# Altium schematic sheet heating_schematic_1.SchDoc (continued)

## Library data of the parts
C1 = EEU-FC1H471L: Capacitor Aluminum Electrolytic 470uF 50 Volt 20% (10 X 30mm) Radial 5mm 1610mA 3000h 105C Bulk; Manufacturer: Panasonic; Manufacturer Lifecycle Status: ACTIVE; Part Series: FC-A; Dielectric Material: Aluminium; Dissipation Factor: 10%; ESR (Equivalent Series Resistance): 60mΩ; Lead Diameter: 600µm; Leakage Current: 235µA; Life (Hours): 3000hours; Ripple Current: 1.61A; Voltage: 50V; Case/Package: Radial; Category: Aluminum Electrolytic Capacitors; Component Kind: Standard; ComponentLink1Description: https://datasheet.octopart.com:443/EEU-FC1H471L-Panasonic-datasheet-68300023.pdf?src-supplier=Panasonic; ComponentLink1URL: https://datasheet.octopart.com:443/EEU-FC1H471L-Panasonic-datasheet-68300023.pdf?src-supplier=Panasonic; ComponentLink2Description: https://datasheet.octopart.com:443/EEU-FC1H471L-Panasonic-datasheet-13266282.pdf?src-supplier=Farnell; ComponentLink2URL: https://datasheet.octopart.com:443/EEU-FC1H471L-Panasonic-datasheet-13266282.pdf?src-supplier=Farnell; ComponentLink3Description: https://datasheet.octopart.com:443/EEU-FC1H471L-Panasonic-datasheet-5313828.pdf?src-supplier=Farnell; ComponentLink3URL: https://datasheet.octopart.com:443/EEU-FC1H471L-Panasonic-datasheet-5313828.pdf?src-supplier=Farnell; ComponentLink4Description: https://datasheet.octopart.com:443/EEU-FC1H471L-Panasonic-datasheet-136608605.pdf?src-supplier=Farnell; ComponentLink4URL: https://datasheet.octopart.com:443/EEU-FC1H471L-Panasonic-datasheet-136608605.pdf?src-supplier=Farnell; ComponentLink5Description: https://datasheet.octopart.com:443/EEU-FC1H471L-Panasonic-datasheet-42901146.pdf?src-supplier=Newark; ComponentLink5URL: https://datasheet.octopart.com:443/EEU-FC1H471L-Panasonic-datasheet-42901146.pdf?src-supplier=Newark; ComponentLink6Description: https://datasheet.octopart.com:443/EEU-FC1H471L-Panasonic-datasheet-38887810.pdf?src-supplier=Newark; ComponentLink6URL: https://datasheet.octopart.com:443/EEU-FC1H471L-Panasonic-datasheet-38887810.pdf?src-supplier=Newark; ComponentLink7Description: https://datasheet.octopart.com:443/EEU-FC1H471L-Panasonic-datasheet-154766098.pdf?src-supplier=Master+Electronics; ComponentLink7URL: https://datasheet.octopart.com:443/EEU-FC1H471L-Panasonic-datasheet-154766098.pdf?src-supplier=Master+Electronics; ComponentLink8Description: https://datasheet.octopart.com:443/EEU-FC1H471L-Panasonic-datasheet-10025751.pdf?src-supplier=RS+(Formerly+Allied+Electronics); ComponentLink8URL: https://datasheet.octopart.com:443/EEU-FC1H471L-Panasonic-datasheet-10025751.pdf?src-supplier=RS+(Formerly+Allied+Electronics); ComponentLink9Description: https://datasheet.octopart.com:443/EEU-FC1H471L-Panasonic-datasheet-22087617.pdf?src-supplier=Electro+Sonic; ComponentLink9URL: https://datasheet.octopart.com:443/EEU-FC1H471L-Panasonic-datasheet-22087617.pdf?src-supplier=Electro+Sonic; ComponentLink10Description: https://datasheet.octopart.com:443/EEUFC1H471L-Panasonic-datasheet-150469839.pdf?src-supplier=TME; ComponentLink10URL: https://datasheet.octopart.com:443/EEUFC1H471L-Panasonic-datasheet-150469839.pdf?src-supplier=TME; ComponentLink11Description: https://datasheet.octopart.com:443/EEU-FC1H471L-Panasonic-datasheet-2252.pdf?src-supplier=DigiKey; ComponentLink11URL: https://datasheet.octopart.com:443/EEU-FC1H471L-Panasonic-datasheet-2252.pdf?src-supplier=DigiKey; Diameter: 10mm; Height: 32mm; Height - Seated (Max): 29.9974mm; Impedance: 60mΩ; Lead Free: Lead Free; Lead Pitch: 5mm; Length: 30mm; Library Name: Simulation Generic Components.IntLib; Lifecycle Status: Production; Manufacturer Part Number: EEU-FC1H471L; Material: Aluminium; Max Operating Temperature: 105°C; Min Operating Temperature: -55°C; Mounting Technology: PCB, Through Hole; Number of Terminals: 2; Packaging: Bulk; Pins: 2; Pricing: 1=1.39, 10=0.897, 50=0.6894, 100=0.6237, 500=0.51066, 1000=0.47503, 2500=0.43702, 5000=0.41386 (USD); Radiation Hardening: No; REACH SVHC: Yes; RoHS: Compliant; Schedule B: 8532220020, 8532220020|8532220020, 8532220020|8532220020|8532220020, 8532220020|8532220020|8532220020|8532220020; Stock: 46796; Supplier: DigiKey; Supplier Part Number: P11260-ND; Terminal Pitch: 5mm; Termination: Radial; Tolerance: 20%; Voltage Rating: 50V; Voltage Rating (DC): 50V; Width: 10mm
C2 = CC0603KRX7R9BB104: Multilayer Ceramic Capacitor, CC Series, 0.1 - F, - 10%, X7R, 50 V, 0603 [1608 Metric]; Manufacturer: Yageo Group; Voltage: 50V; Case Code (Imperial): 0603; Case Code (Metric): 1608; Case/Package: 0603; Category: Ceramic Capacitors; China RoHS: Compliant; Component Kind: Standard; ComponentLink1Description: https://datasheet.octopart.com:443/CC0603KRX7R9BB104-Yageo-datasheet-177165144.pdf?src-supplier=IHS; ComponentLink1URL: https://datasheet.octopart.com:443/CC0603KRX7R9BB104-Yageo-datasheet-177165144.pdf?src-supplier=IHS; ComponentLink2Description: https://datasheet.octopart.com:443/CC0603KRX7R9BB104-Yageo-datasheet-82288757.pdf?src-supplier=Upverter; ComponentLink2URL: https://datasheet.octopart.com:443/CC0603KRX7R9BB104-Yageo-datasheet-82288757.pdf?src-supplier=Upverter; ComponentLink3Description: https://datasheet.octopart.com:443/CC0603KRX7R9BB104-Yageo-datasheet-68298032.pdf?src-supplier=Upverter; ComponentLink3URL: https://datasheet.octopart.com:443/CC0603KRX7R9BB104-Yageo-datasheet-68298032.pdf?src-supplier=Upverter; ComponentLink4Description: https://datasheet.octopart.com:443/CC0603KRX7R9BB104-Yageo-Group-datasheet-189192896.pdf?src-supplier=Future+Electronics; ComponentLink4URL: https://datasheet.octopart.com:443/CC0603KRX7R9BB104-Yageo-Group-datasheet-189192896.pdf?src-supplier=Future+Electronics; ComponentLink5Description: https://datasheet.octopart.com:443/CC0603KRX7R9BB104-Yageo-datasheet-12526321.pdf?src-supplier=Future+Electronics; ComponentLink5URL: https://datasheet.octopart.com:443/CC0603KRX7R9BB104-Yageo-datasheet-12526321.pdf?src-supplier=Future+Electronics; ComponentLink6Description: https://datasheet.octopart.com:443/CC0603KRX7R9BB104-Yageo-datasheet-166511530.pdf?src-supplier=Future+Electronics; ComponentLink6URL: https://datasheet.octopart.com:443/CC0603KRX7R9BB104-Yageo-datasheet-166511530.pdf?src-supplier=Future+Electronics; ComponentLink7Description: https://datasheet.octopart.com:443/CC0603KRX7R9BB104-Yageo-datasheet-137700082.pdf?src-supplier=Future+Electronics; ComponentLink7URL: https://datasheet.octopart.com:443/CC0603KRX7R9BB104-Yageo-datasheet-137700082.pdf?src-supplier=Future+Electronics; ComponentLink8Description: https://datasheet.octopart.com:443/CC0603KRX7R9BB104-Yageo-datasheet-137700084.pdf?src-supplier=Future+Electronics; ComponentLink8URL: https://datasheet.octopart.com:443/CC0603KRX7R9BB104-Yageo-datasheet-137700084.pdf?src-supplier=Future+Electronics; ComponentLink9Description: https://datasheet.octopart.com:443/CC0603KRX7R9BB104-Yageo-datasheet-27626582.pdf?src-supplier=Future+Electronics; ComponentLink9URL: https://datasheet.octopart.com:443/CC0603KRX7R9BB104-Yageo-datasheet-27626582.pdf?src-supplier=Future+Electronics; ComponentLink10Description: https://datasheet.octopart.com:443/CC0603KRX7R9BB104-Yageo-datasheet-15895757.pdf?src-supplier=Future+Electronics; ComponentLink10URL: https://datasheet.octopart.com:443/CC0603KRX7R9BB104-Yageo-datasheet-15895757.pdf?src-supplier=Future+Electronics; ComponentLink11Description: https://datasheet.octopart.com:443/CC0603KRX7R9BB104-Yageo-datasheet-8324192.pdf?src-supplier=Future+Electronics; ComponentLink11URL: https://datasheet.octopart.com:443/CC0603KRX7R9BB104-Yageo-datasheet-8324192.pdf?src-supplier=Future+Electronics; ComponentLink12Description: https://datasheet.octopart.com/CC0603KRX7R9BB104-Yageo-datasheet-10883670.pdf; ComponentLink12URL: https://datasheet.octopart.com/CC0603KRX7R9BB104-Yageo-datasheet-10883670.pdf; ComponentLink13Description: https://datasheet.octopart.com:443/CC0603KRX7R9BB104-Yageo-datasheet-181968726.pdf?src-supplier=Newark; ComponentLink13URL: https://datasheet.octopart.com:443/CC0603KRX7R9BB104-Yageo-datasheet-181968726.pdf?src-supplier=Newark; ComponentLink14Description: https://datasheet.octopart.com:443/CC0603KRX7R9BB104-Yageo-datasheet-499490.pdf?src-supplier=Newark; ComponentLink14URL: https://datasheet.octopart.com:443/CC0603KRX7R9BB104-Yageo-datasheet-499490.pdf?src-supplier=Newark; ComponentLink15Description: https://datasheet.octopart.com:443/CC0603KRX7R9BB104-Yageo-datasheet-62336348.pdf?src-supplier=Newark; ComponentLink15URL: https://datasheet.octopart.com:443/CC0603KRX7R9BB104-Yageo-datasheet-62336348.pdf?src-supplier=Newark; ComponentLink16Description: https://datasheet.octopart.com:443/CC0603KRX7R9BB104-Yageo-datasheet-147453679.pdf?src-supplier=Newark; ComponentLink16URL: https://datasheet.octopart.com:443/CC0603KRX7R9BB104-Yageo-datasheet-147453679.pdf?src-supplier=Newark; ComponentLink17Description: https://datasheet.octopart.com:443/CC0603KRX7R9BB104-Yageo-datasheet-151948686.pdf?src-supplier=Farnell; ComponentLink17URL: https://datasheet.octopart.com:443/CC0603KRX7R9BB104-Yageo-datasheet-151948686.pdf?src-supplier=Farnell; ComponentLink18Description: https://datasheet.octopart.com:443/CC0603KRX7R9BB104-Yageo-datasheet-500777.pdf?src-supplier=Farnell; ComponentLink18URL: https://datasheet.octopart.com:443/CC0603KRX7R9BB104-Yageo-datasheet-500777.pdf?src-supplier=Farnell; ComponentLink19Description: https://datasheet.octopart.com:443/CC0603KRX7R9BB104-Yageo-datasheet-178019014.pdf?src-supplier=Burklin+Elektronik; ComponentLink19URL: https://datasheet.octopart.com:443/CC0603KRX7R9BB104-Yageo-datasheet-178019014.pdf?src-supplier=Burklin+Elektronik; ComponentLink20Description: https://datasheet.octopart.com:443/CC0603KRX7R9BB104-Yageo-Group-datasheet-165992755.pdf?src-supplier=ODG+(Origin+Data+Global); ComponentLink20URL: https://datasheet.octopart.com:443/CC0603KRX7R9BB104-Yageo-Group-datasheet-165992755.pdf?src-supplier=ODG+(Origin+Data+Global); ComponentLink21Description: https://datasheet.octopart.com:443/CC0603KRX7R9BB104-Yageo-datasheet-140260305.pdf?src-supplier=SOS+electronic; ComponentLink21URL: https://datasheet.octopart.com:443/CC0603KRX7R9BB104-Yageo-datasheet-140260305.pdf?src-supplier=SOS+electronic; ComponentLink22Description: https://datasheet.octopart.com:443/CC0603KRX7R9BB104-Yageo-datasheet-120101875.pdf?src-supplier=Megastar; ComponentLink22URL: https://datasheet.octopart.com:443/CC0603KRX7R9BB104-Yageo-datasheet-120101875.pdf?src-supplier=Megastar; ComponentLink23Description: https://datasheet.octopart.com:443/CC0603KRX7R9BB104-Yageo-datasheet-8701427.pdf?src-supplier=element14; ComponentLink23URL: https://datasheet.octopart.com:443/CC0603KRX7R9BB104-Yageo-datasheet-8701427.pdf?src-supplier=element14; ComponentLink24Description: https://datasheet.octopart.com:443/CC0603KRX7R9BB104-Yageo-datasheet-5301394.pdf?src-supplier=element14; ComponentLink24URL: https://datasheet.octopart.com:443/CC0603KRX7R9BB104-Yageo-datasheet-5301394.pdf?src-supplier=element14; ComponentLink25Description: https://datasheet.octopart.com:443/CC0603KRX7R9BB104-Yageo-datasheet-17600895.pdf?src-supplier=TME; ComponentLink25URL: https://datasheet.octopart.com:443/CC0603KRX7R9BB104-Yageo-datasheet-17600895.pdf?src-supplier=TME; ComponentLink26Description: http://sigma.octopart.com:80/15889575/rohs_statement/Yageo-CC0603KRX7R9BB104.pdf?src-supplier=Compliance+Statements; ComponentLink26URL: http://sigma.octopart.com:80/15889575/rohs_statement/Yageo-CC0603KRX7R9BB104.pdf?src-supplier=Compliance+Statements; ComponentLink27Description: http://sigma.octopart.com:80/conflict_mineral_statement/Yageo-company-3.pdf?src-supplier=Compliance+Statements; ComponentLink27URL: http://sigma.octopart.com:80/conflict_mineral_statement/Yageo-company-3.pdf?src-supplier=Compliance+Statements; Country of Origin: Mainland China, Taiwan; Depth: 800µm; Dielectric: X7R; Dielectric Material: Ceramic; Export Control Classification Number (ECCN) Code: EAR99; Halogen Free: Halogen Free; Harmonized Tariff Schedule (HTS) Code: 8532.24.00.20; Height: 800µm; Introduction Date: 2005-08-18; Lead Free: Lead Free; Length: 1.6mm; Library Name: Simulation Generic Components.IntLib; Lifecycle Status: Production; Manufacturer Part Number: CC0603KRX7R9BB104; Material: Ceramic; Max Operating Temperature: 125°C; Min Operating Temperature: -55°C; Mounting Technology: Surface Mount; Number of Terminals: 2; Pins: 2; Pricing: 1=0.08, 10=0.024, 50=0.0176, 100=0.0112, 500=0.0104, 1000=0.0096 (USD); Radiation Hardening: No; REACH SVHC: Yes; RoHS: Compliant; Schedule B: 8532240020, 8532240020|8532240020, 8532240020|8532240020|8532240020, 8532240020|8532240020|8532240020|8532240020; Stock: 2142675; Supplier: DigiKey; Supplier Part Number: 311-1344-1-ND; Termination: SMD/SMT; Thickness: 889µm; Tolerance: 10%; Voltage Rating: 50V; Voltage Rating (DC): 50V; Width: 800µm
C3 = CC0603KRX7R9BB105: 50V 1uF X7R ±10% 0603 Multilayer Ceramic Capacitors MLCC - SMD/SMT ROHS; Manufacturer: Yageo Group; Case Code (Imperial): 0603; Case Code (Metric): 1608; Case/Package: 0603; Category: Ceramic Capacitors; China RoHS: Compliant; Component Kind: Standard; ComponentLink1Description: https://datasheet.octopart.com:443/CC0603KRX7R9BB105-Yageo-datasheet-177165144.pdf?src-supplier=IHS; ComponentLink1URL: https://datasheet.octopart.com:443/CC0603KRX7R9BB105-Yageo-datasheet-177165144.pdf?src-supplier=IHS; ComponentLink2Description: https://datasheet.octopart.com:443/CC0603KRX7R9BB105-Yageo-datasheet-82288757.pdf?src-supplier=Upverter; ComponentLink2URL: https://datasheet.octopart.com:443/CC0603KRX7R9BB105-Yageo-datasheet-82288757.pdf?src-supplier=Upverter; ComponentLink3Description: https://datasheet.octopart.com:443/CC0603KRX7R9BB105-Yageo-datasheet-149070022.pdf?src-supplier=Upverter; ComponentLink3URL: https://datasheet.octopart.com:443/CC0603KRX7R9BB105-Yageo-datasheet-149070022.pdf?src-supplier=Upverter; ComponentLink4Description: https://sigma.octopart.com:443/147830939/technical_drawing/Yageo-CC0603KRX7R9BB105.pdf?src-supplier=Upverter; ComponentLink4URL: https://sigma.octopart.com:443/147830939/technical_drawing/Yageo-CC0603KRX7R9BB105.pdf?src-supplier=Upverter; ComponentLink5Description: https://datasheet.octopart.com:443/CC0603KRX7R9BB105-Yageo-datasheet-181968729.pdf?src-supplier=Newark; ComponentLink5URL: https://datasheet.octopart.com:443/CC0603KRX7R9BB105-Yageo-datasheet-181968729.pdf?src-supplier=Newark; ComponentLink6Description: https://datasheet.octopart.com:443/CC0603KRX7R9BB105-Yageo-datasheet-147453679.pdf?src-supplier=Newark; ComponentLink6URL: https://datasheet.octopart.com:443/CC0603KRX7R9BB105-Yageo-datasheet-147453679.pdf?src-supplier=Newark; ComponentLink7Description: https://datasheet.octopart.com:443/CC0603KRX7R9BB105-Yageo-datasheet-181964739.pdf?src-supplier=Farnell; ComponentLink7URL: https://datasheet.octopart.com:443/CC0603KRX7R9BB105-Yageo-datasheet-181964739.pdf?src-supplier=Farnell; ComponentLink8Description: https://datasheet.octopart.com:443/CC0603KRX7R9BB105-Yageo-datasheet-151948686.pdf?src-supplier=TME; ComponentLink8URL: https://datasheet.octopart.com:443/CC0603KRX7R9BB105-Yageo-datasheet-151948686.pdf?src-supplier=TME; ComponentLink9Description: https://datasheet.octopart.com:443/CC0603KRX7R9BB105-Yageo-datasheet-166511530.pdf?src-supplier=Future+Electronics; ComponentLink9URL: https://datasheet.octopart.com:443/CC0603KRX7R9BB105-Yageo-datasheet-166511530.pdf?src-supplier=Future+Electronics; ComponentLink10Description: https://datasheet.octopart.com:443/CC0603KRX7R9BB105-Yageo-datasheet-137700082.pdf?src-supplier=Future+Electronics; ComponentLink10URL: https://datasheet.octopart.com:443/CC0603KRX7R9BB105-Yageo-datasheet-137700082.pdf?src-supplier=Future+Electronics; ComponentLink11Description: https://datasheet.octopart.com:443/CC0603KRX7R9BB105-Yageo-Group-datasheet-165992755.pdf?src-supplier=ODG+(Origin+Data+Global); ComponentLink11URL: https://datasheet.octopart.com:443/CC0603KRX7R9BB105-Yageo-Group-datasheet-165992755.pdf?src-supplier=ODG+(Origin+Data+Global); ComponentLink12Description: https://datasheet.octopart.com/Surface-Mount-Ceramic-Multilayer-Capacitors%3B-General-Purpose-%26-High-Capacitance-Class-2%2C-X7R-Yageo-datasheet-family-114.pdf; ComponentLink12URL: https://datasheet.octopart.com/Surface-Mount-Ceramic-Multilayer-Capacitors%3B-General-Purpose-%26-High-Capacitance-Class-2%2C-X7R-Yageo-datasheet-family-114.pdf; ComponentLink13Description: https://datasheet.octopart.com/CC0603KRX7R9BB105-Yageo-datasheet-10883670.pdf; ComponentLink13URL: https://datasheet.octopart.com/CC0603KRX7R9BB105-Yageo-datasheet-10883670.pdf; ComponentLink14Description: http://sigma.octopart.com:80/rohs_statement/Surface-Mount-Ceramic-Multilayer-Capacitors%3B-General-Purpose-%26-High-Capacitance-Class-2%2C-X7R-Yageo-family-124.pdf?src-supplier=Compliance+Statements; ComponentLink14URL: http://sigma.octopart.com:80/rohs_statement/Surface-Mount-Ceramic-Multilayer-Capacitors%3B-General-Purpose-%26-High-Capacitance-Class-2%2C-X7R-Yageo-family-124.pdf?src-supplier=Compliance+Statements; ComponentLink15Description: http://sigma.octopart.com:80/reach_statement/Surface-Mount-Ceramic-Multilayer-Capacitors%3B-General-Purpose-%26-High-Capacitance-Class-2%2C-X7R-Yageo-family-125.pdf?src-supplier=Compliance+Statements; ComponentLink15URL: http://sigma.octopart.com:80/reach_statement/Surface-Mount-Ceramic-Multilayer-Capacitors%3B-General-Purpose-%26-High-Capacitance-Class-2%2C-X7R-Yageo-family-125.pdf?src-supplier=Compliance+Statements; ComponentLink16Description: http://sigma.octopart.com:80/conflict_mineral_statement/Yageo-company-3.pdf?src-supplier=Compliance+Statements; ComponentLink16URL: http://sigma.octopart.com:80/conflict_mineral_statement/Yageo-company-3.pdf?src-supplier=Compliance+Statements; ComponentLink17Description: http://sigma.octopart.com:80/rohs_statement/Yageo-company-2.pdf?src-supplier=Compliance+Statements; ComponentLink17URL: http://sigma.octopart.com:80/rohs_statement/Yageo-company-2.pdf?src-supplier=Compliance+Statements; Composition: Ceramic; Country of Origin: Mainland China, Taiwan; Dielectric: X7R; Dielectric Material: Ceramic; Export Control Classification Number (ECCN) Code: EAR99; Halogen Free: Halogen Free; Harmonized Tariff Schedule (HTS) Code: 8532.24.00.20; Height: 800µm; Introduction Date: 2014-07-08; Lead Free: Lead Free; Length: 1.6mm; Library Name: Simulation Generic Components.IntLib; Lifecycle Status: Production; Manufacturer Part Number: CC0603KRX7R9BB105; Material: Ceramic; Max Operating Temperature: 125°C; Min Operating Temperature: -55°C; Mounting Technology: Surface Mount; Number of Terminals: 2; Packaging: Tape & Reel (TR); Pricing: 1=0.47, 10=0.284, 50=0.2124, 100=0.1902, 500=0.15282, 1000=0.14128, 4000=0.12356, 8000=0.11755, 12000=0.11433, 20000=0.11245 (USD); REACH SVHC: Yes; RoHS: Compliant; Schedule B: 8532240020, 8532240020|8532240020|8532240020|8532240020|8532240020; Stock: 144229; Supplier: DigiKey; Supplier Part Number: 311-2082-1-ND; Termination: SMD/SMT; Thickness: 889µm; Tolerance: 10%; Voltage Rating: 50V; Voltage Rating (DC): 50V; Weight: 2.012816mg; Width: 800µm
C4 = GRM32ER72A225KA35L: IRON_BUCK_CIN: 100V 2.2uF X7R ±10% 1210 Multilayer Ceramic Capacitors MLCC - SMD/SMT ROHS; Manufacturer: Murata; Manufacturer Lifecycle Status: NRND; Case Code (Imperial): 1210; Case Code (Metric): 3225; Case/Package: 1210; Category: Ceramic Capacitors; Component Kind: Standard; ComponentLink1Description: https://datasheet.octopart.com:443/GRM32ER72A225KA35L-Murata-datasheet-11840456.pdf?src-supplier=_legacy+Avnet; ComponentLink1URL: https://datasheet.octopart.com:443/GRM32ER72A225KA35L-Murata-datasheet-11840456.pdf?src-supplier=_legacy+Avnet; ComponentLink2Description: https://datasheet.octopart.com:443/GRM32ER72A225KA35L-Murata-datasheet-8817987.pdf?src-supplier=element14+APAC; ComponentLink2URL: https://datasheet.octopart.com:443/GRM32ER72A225KA35L-Murata-datasheet-8817987.pdf?src-supplier=element14+APAC; ComponentLink3Description: https://datasheet.octopart.com:443/GRM32ER72A225KA35L-Murata-datasheet-62320274.pdf?src-supplier=element14+APAC; ComponentLink3URL: https://datasheet.octopart.com:443/GRM32ER72A225KA35L-Murata-datasheet-62320274.pdf?src-supplier=element14+APAC; ComponentLink4Description: https://datasheet.octopart.com:443/GRM32ER72A225KA35L-Murata-datasheet-8376856.pdf?src-supplier=Future+Electronics; ComponentLink4URL: https://datasheet.octopart.com:443/GRM32ER72A225KA35L-Murata-datasheet-8376856.pdf?src-supplier=Future+Electronics; ComponentLink5Description: https://datasheet.octopart.com:443/GRM32ER72A225KA35L-Murata-datasheet-14397864.pdf?src-supplier=Future+Electronics; ComponentLink5URL: https://datasheet.octopart.com:443/GRM32ER72A225KA35L-Murata-datasheet-14397864.pdf?src-supplier=Future+Electronics; ComponentLink6Description: https://datasheet.octopart.com:443/GRM32ER72A225KA35L-Murata-datasheet-15666908.pdf?src-supplier=Future+Electronics; ComponentLink6URL: https://datasheet.octopart.com:443/GRM32ER72A225KA35L-Murata-datasheet-15666908.pdf?src-supplier=Future+Electronics; ComponentLink7Description: https://datasheet.octopart.com:443/GRM32ER72A225KA35L-Murata-datasheet-12510170.pdf?src-supplier=Future+Electronics; ComponentLink7URL: https://datasheet.octopart.com:443/GRM32ER72A225KA35L-Murata-datasheet-12510170.pdf?src-supplier=Future+Electronics; ComponentLink8Description: https://datasheet.octopart.com:443/GRM32ER72A225KA35L-Murata-datasheet-8517407.pdf?src-supplier=Future+Electronics; ComponentLink8URL: https://datasheet.octopart.com:443/GRM32ER72A225KA35L-Murata-datasheet-8517407.pdf?src-supplier=Future+Electronics; ComponentLink9Description: https://datasheet.octopart.com:443/GRM32ER72A225KA35L-Murata-datasheet-8387045.pdf?src-supplier=Future+Electronics; ComponentLink9URL: https://datasheet.octopart.com:443/GRM32ER72A225KA35L-Murata-datasheet-8387045.pdf?src-supplier=Future+Electronics; ComponentLink10Description: https://datasheet.octopart.com:443/GRM32ER72A225KA35L-Murata-datasheet-8324201.pdf?src-supplier=Future+Electronics; ComponentLink10URL: https://datasheet.octopart.com:443/GRM32ER72A225KA35L-Murata-datasheet-8324201.pdf?src-supplier=Future+Electronics; ComponentLink11Description: https://datasheet.octopart.com:443/GRM32ER72A225KA35L-Murata-datasheet-7628724.pdf?src-supplier=Future+Electronics; ComponentLink11URL: https://datasheet.octopart.com:443/GRM32ER72A225KA35L-Murata-datasheet-7628724.pdf?src-supplier=Future+Electronics; ComponentLink12Description: https://datasheet.octopart.com:443/GRM32ER72A225KA35L-Murata-datasheet-552801.pdf?src-supplier=Future+Electronics; ComponentLink12URL: https://datasheet.octopart.com:443/GRM32ER72A225KA35L-Murata-datasheet-552801.pdf?src-supplier=Future+Electronics; ComponentLink13Description: https://datasheet.octopart.com:443/GRM32ER72A225KA35L-Murata-datasheet-44109779.pdf?src-supplier=Future+Electronics; ComponentLink13URL: https://datasheet.octopart.com:443/GRM32ER72A225KA35L-Murata-datasheet-44109779.pdf?src-supplier=Future+Electronics; ComponentLink14Description: https://datasheet.octopart.com:443/GRM32ER72A225KA35L-Murata-datasheet-8138834.pdf?src-supplier=Newark; ComponentLink14URL: https://datasheet.octopart.com:443/GRM32ER72A225KA35L-Murata-datasheet-8138834.pdf?src-supplier=Newark; ComponentLink15Description: https://datasheet.octopart.com:443/GRM32ER72A225KA35L-Murata-datasheet-165579666.pdf?src-supplier=TME; ComponentLink15URL: https://datasheet.octopart.com:443/GRM32ER72A225KA35L-Murata-datasheet-165579666.pdf?src-supplier=TME; ComponentLink16Description: https://datasheet.octopart.com:443/GRM32ER72A225KA35L-Murata-datasheet-59876780.pdf?src-supplier=TME; ComponentLink16URL: https://datasheet.octopart.com:443/GRM32ER72A225KA35L-Murata-datasheet-59876780.pdf?src-supplier=TME; ComponentLink17Description: https://datasheet.octopart.com:443/GRM32ER72A225KA35L-Murata-datasheet-140709649.pdf?src-supplier=Ciiva; ComponentLink17URL: https://datasheet.octopart.com:443/GRM32ER72A225KA35L-Murata-datasheet-140709649.pdf?src-supplier=Ciiva; ComponentLink18Description: https://datasheet.octopart.com:443/GRM32ER72A225KA35L-Murata-datasheet-138747052.pdf?src-supplier=Ciiva; ComponentLink18URL: https://datasheet.octopart.com:443/GRM32ER72A225KA35L-Murata-datasheet-138747052.pdf?src-supplier=Ciiva; ComponentLink19Description: https://datasheet.octopart.com/GRM32ER72A225KA35L-Murata-datasheet-12515295.pdf; ComponentLink19URL: https://datasheet.octopart.com/GRM32ER72A225KA35L-Murata-datasheet-12515295.pdf; ComponentLink20Description: https://datasheet.octopart.com/GRM32ER72A225KA35L-Murata-datasheet-12510076.pdf; ComponentLink20URL: https://datasheet.octopart.com/GRM32ER72A225KA35L-Murata-datasheet-12510076.pdf; ComponentLink21Description: https://datasheet.octopart.com/GRM32ER72A225KA35L-Murata-datasheet-11978611.pdf; ComponentLink21URL: https://datasheet.octopart.com/GRM32ER72A225KA35L-Murata-datasheet-11978611.pdf; ComponentLink22Description: https://datasheet.octopart.com/GRM32ER72A225KA35L-Murata-datasheet-10025737.pdf; ComponentLink22URL: https://datasheet.octopart.com/GRM32ER72A225KA35L-Murata-datasheet-10025737.pdf; ComponentLink23Description: https://datasheet.octopart.com/GRM32ER72A225KA35L-Murata-datasheet-8819587.pdf; ComponentLink23URL: https://datasheet.octopart.com/GRM32ER72A225KA35L-Murata-datasheet-8819587.pdf; ComponentLink24Description: https://datasheet.octopart.com:443/GRM32ER72A225KA35L-Murata-datasheet-14047325.pdf?src-supplier=iiiC; ComponentLink24URL: https://datasheet.octopart.com:443/GRM32ER72A225KA35L-Murata-datasheet-14047325.pdf?src-supplier=iiiC; ComponentLink25Description: https://datasheet.octopart.com:443/GRM32ER72A225KA35L-Murata-datasheet-7550257.pdf?src-supplier=Farnell; ComponentLink25URL: https://datasheet.octopart.com:443/GRM32ER72A225KA35L-Murata-datasheet-7550257.pdf?src-supplier=Farnell; ComponentLink26Description: https://datasheet.octopart.com:443/GRM32ER72A225KA35L.-Murata-datasheet-5332913.pdf?src-supplier=Farnell; ComponentLink26URL: https://datasheet.octopart.com:443/GRM32ER72A225KA35L.-Murata-datasheet-5332913.pdf?src-supplier=Farnell; ComponentLink27Description: https://datasheet.octopart.com:443/GRM32ER72A225KA35L-Murata-datasheet-118811.pdf?src-supplier=DigiKey; ComponentLink27URL: https://datasheet.octopart.com:443/GRM32ER72A225KA35L-Murata-datasheet-118811.pdf?src-supplier=DigiKey; ComponentLink28Description: http://sigma.octopart.com:80/reach_statement/Murata-company-16.pdf?src-supplier=Compliance+Statements; ComponentLink28URL: http://sigma.octopart.com:80/reach_statement/Murata-company-16.pdf?src-supplier=Compliance+Statements; Depth: 2.5mm; Dielectric: X7R; Dielectric Material: Ceramic; Export Control Classification Number (ECCN) Code: EAR99; Harmonized Tariff Schedule (HTS) Code: 8532.24.00.20; Height: 2.5mm; Introduction Date: 2005-06-23; Lead Free: Lead Free; Length: 3.2mm; Library Name: Simulation Generic Components.IntLib; Lifecycle Status: NRND; Manufacturer Part Number: GRM32ER72A225KA35L; Material: Ceramic; Max Operating Temperature: 125°C; Min Operating Temperature: -55°C; Mounting Technology: Surface Mount; Number of Terminals: 2; Packaging: Cut Tape; Pins: 2; Pricing: 1=1.66, 10=1.106, 50=0.8862, 100=0.8183, 500=0.7034 (USD); REACH SVHC: Yes; RoHS: Compliant; Schedule B: 8532240020, 8532240020|8532240020|8532240020|8532240020|8532240020|8532240020|8532240020|8532240020|8532240020|8532240020|8532240020|8532240020|8532240020|8532240020|8532240020|8532240020|8532240020|8532240020|8532240020|8532240020|8532240020|8532240020|8532240020|85; Stock: 72648; Supplier: DigiKey; Supplier Part Number: 490-3385-1-ND; Termination: SMD/SMT; Thickness: 2.5mm; Tolerance: 10%; Voltage: 100V; Voltage Rating: 100V; Voltage Rating (DC): 100V; Width: 2.5mm
C5 = GRM188R72A104KA35D: IRON_BUCK_CHF: 100V 100nF X7R ±10% 0603 Multilayer Ceramic Capacitors MLCC - SMD/SMT ROHS; Manufacturer: Murata; Manufacturer Lifecycle Status: IN PRODUCTION; Case Code (Imperial): 0603; Case Code (Metric): 1608; Case/Package: 0603; Category: Ceramic Capacitors; Component Kind: Standard; ComponentLink1Description: https://datasheet.octopart.com:443/GRM188R72A104KA35D-Murata-datasheet-44108070.pdf?src-supplier=Upverter; ComponentLink1URL: https://datasheet.octopart.com:443/GRM188R72A104KA35D-Murata-datasheet-44108070.pdf?src-supplier=Upverter; ComponentLink2Description: https://datasheet.octopart.com:443/GRM188R72A104KA35D-Murata-datasheet-141275792.pdf?src-supplier=Future+Electronics; ComponentLink2URL: https://datasheet.octopart.com:443/GRM188R72A104KA35D-Murata-datasheet-141275792.pdf?src-supplier=Future+Electronics; ComponentLink3Description: https://datasheet.octopart.com:443/GRM188R72A104KA35D-Murata-datasheet-32479577.pdf?src-supplier=Future+Electronics; ComponentLink3URL: https://datasheet.octopart.com:443/GRM188R72A104KA35D-Murata-datasheet-32479577.pdf?src-supplier=Future+Electronics; ComponentLink4Description: https://datasheet.octopart.com:443/GRM188R72A104KA35D-Murata-datasheet-14397338.pdf?src-supplier=Future+Electronics; ComponentLink4URL: https://datasheet.octopart.com:443/GRM188R72A104KA35D-Murata-datasheet-14397338.pdf?src-supplier=Future+Electronics; ComponentLink5Description: https://datasheet.octopart.com:443/GRM188R72A104KA35D-Murata-datasheet-62317223.pdf?src-supplier=element14+APAC; ComponentLink5URL: https://datasheet.octopart.com:443/GRM188R72A104KA35D-Murata-datasheet-62317223.pdf?src-supplier=element14+APAC; ComponentLink6Description: https://datasheet.octopart.com:443/GRM188R72A104KA35D-Murata-datasheet-17853129.pdf?src-supplier=element14+APAC; ComponentLink6URL: https://datasheet.octopart.com:443/GRM188R72A104KA35D-Murata-datasheet-17853129.pdf?src-supplier=element14+APAC; ComponentLink7Description: https://datasheet.octopart.com:443/GRM188R72A104KA35D-Murata-datasheet-8817987.pdf?src-supplier=Newark; ComponentLink7URL: https://datasheet.octopart.com:443/GRM188R72A104KA35D-Murata-datasheet-8817987.pdf?src-supplier=Newark; ComponentLink8Description: https://datasheet.octopart.com:443/GRM188R72A104KA35D-Murata-datasheet-5396244.pdf?src-supplier=_legacy+Avnet; ComponentLink8URL: https://datasheet.octopart.com:443/GRM188R72A104KA35D-Murata-datasheet-5396244.pdf?src-supplier=_legacy+Avnet; ComponentLink9Description: https://datasheet.octopart.com/GRM188R72A104KA35D-Murata-datasheet-12546599.pdf; ComponentLink9URL: https://datasheet.octopart.com/GRM188R72A104KA35D-Murata-datasheet-12546599.pdf; ComponentLink10Description: https://datasheet.octopart.com/GRM188R72A104KA35D-Murata-datasheet-12533551.pdf; ComponentLink10URL: https://datasheet.octopart.com/GRM188R72A104KA35D-Murata-datasheet-12533551.pdf; ComponentLink11Description: https://datasheet.octopart.com/GRM188R72A104KA35D-Murata-datasheet-12515295.pdf; ComponentLink11URL: https://datasheet.octopart.com/GRM188R72A104KA35D-Murata-datasheet-12515295.pdf; ComponentLink12Description: https://datasheet.octopart.com/GRM188R72A104KA35D-Murata-datasheet-12510076.pdf; ComponentLink12URL: https://datasheet.octopart.com/GRM188R72A104KA35D-Murata-datasheet-12510076.pdf; ComponentLink13Description: https://datasheet.octopart.com/GRM188R72A104KA35D-Murata-datasheet-11978611.pdf; ComponentLink13URL: https://datasheet.octopart.com/GRM188R72A104KA35D-Murata-datasheet-11978611.pdf; ComponentLink14Description: https://datasheet.octopart.com/GRM188R72A104KA35D-Murata-datasheet-10025737.pdf; ComponentLink14URL: https://datasheet.octopart.com/GRM188R72A104KA35D-Murata-datasheet-10025737.pdf; ComponentLink15Description: https://datasheet.octopart.com/GRM188R72A104KA35D-Murata-datasheet-8819587.pdf; ComponentLink15URL: https://datasheet.octopart.com/GRM188R72A104KA35D-Murata-datasheet-8819587.pdf; ComponentLink16Description: https://datasheet.octopart.com:443/GRM188R72A104KA35D-Murata-datasheet-165579666.pdf?src-supplier=TME; ComponentLink16URL: https://datasheet.octopart.com:443/GRM188R72A104KA35D-Murata-datasheet-165579666.pdf?src-supplier=TME; ComponentLink17Description: https://datasheet.octopart.com:443/GRM188R72A104KA35D-Murata-datasheet-59876780.pdf?src-supplier=TME; ComponentLink17URL: https://datasheet.octopart.com:443/GRM188R72A104KA35D-Murata-datasheet-59876780.pdf?src-supplier=TME; ComponentLink18Description: https://datasheet.octopart.com:443/GRM188R72A104KA35D-Murata-datasheet-140705814.pdf?src-supplier=Ciiva; ComponentLink18URL: https://datasheet.octopart.com:443/GRM188R72A104KA35D-Murata-datasheet-140705814.pdf?src-supplier=Ciiva; ComponentLink19Description: https://datasheet.octopart.com:443/GRM188R72A104KA35D-Murata-datasheet-138743342.pdf?src-supplier=Ciiva; ComponentLink19URL: https://datasheet.octopart.com:443/GRM188R72A104KA35D-Murata-datasheet-138743342.pdf?src-supplier=Ciiva; ComponentLink20Description: https://datasheet.octopart.com:443/GRM188R72A104KA35D-Murata-datasheet-120101189.pdf?src-supplier=Megastar; ComponentLink20URL: https://datasheet.octopart.com:443/GRM188R72A104KA35D-Murata-datasheet-120101189.pdf?src-supplier=Megastar; ComponentLink21Description: https://datasheet.octopart.com:443/GRM188R72A104KA35D-Murata-datasheet-7591359.pdf?src-supplier=Jameco; ComponentLink21URL: https://datasheet.octopart.com:443/GRM188R72A104KA35D-Murata-datasheet-7591359.pdf?src-supplier=Jameco; ComponentLink22Description: https://datasheet.octopart.com:443/GRM188R72A104KA35D-Murata-datasheet-118811.pdf?src-supplier=DigiKey; ComponentLink22URL: https://datasheet.octopart.com:443/GRM188R72A104KA35D-Murata-datasheet-118811.pdf?src-supplier=DigiKey; ComponentLink23Description: http://sigma.octopart.com:80/reach_statement/Murata-company-16.pdf?src-supplier=Compliance+Statements; ComponentLink23URL: http://sigma.octopart.com:80/reach_statement/Murata-company-16.pdf?src-supplier=Compliance+Statements; Country of Origin: Philippines; Depth: 800µm; Dielectric: X7R; Dielectric Material: Ceramic; Export Control Classification Number (ECCN) Code: EAR99; Harmonized Tariff Schedule (HTS) Code: 8532.24.00.20; Height: 800µm; Introduction Date: 2006-12-28; Lead Free: Lead Free; Length: 1.6mm; Library Name: Simulation Generic Components.IntLib; Lifecycle Status: Production; Manufacturer Part Number: GRM188R72A104KA35D; Material: Ceramic; Max Operating Temperature: 125°C; Min Operating Temperature: -55°C; Mounting Technology: Surface Mount; Number of Terminals: 2; Packaging: Tape and Reel; Pins: 2; Pricing: 1=0.14, 10=0.079, 50=0.0556, 100=0.0483, 500=0.03618, 1000=0.03244 (USD); Radiation Hardening: No; REACH SVHC: Yes; RoHS: Compliant; Schedule B: 8532240020, 8532240020|8532240020, 8532240020|8532240020|8532240020, 8532240020|8532240020|8532240020|8532240020; Stock: 1666184; Supplier: DigiKey; Supplier Part Number: 490-3285-1-ND; Termination: SMD/SMT; Thickness: 800µm; Tolerance: 10%; Voltage: 100V; Voltage Rating: 100V; Voltage Rating (DC): 100V; Weight: 6.293594mg; Width: 800µm
C6 = EMK107B7105KA-T: IRON_BUCK_CVCC: 16V 1uF X7R ±10% 0603 Multilayer Ceramic Capacitors MLCC - SMD/SMT ROHS; Manufacturer: TAIYO YUDEN; Case Code (Imperial): 0603; Case Code (Metric): 1608; Case/Package: 0603; Category: Ceramic Capacitors; China RoHS: Compliant; Component Kind: Standard; ComponentLink1Description: https://datasheet.octopart.com:443/EMK107B7105KA-T-Taiyo-Yuden-datasheet-182102775.pdf?src-supplier=IHS; ComponentLink1URL: https://datasheet.octopart.com:443/EMK107B7105KA-T-Taiyo-Yuden-datasheet-182102775.pdf?src-supplier=IHS; ComponentLink2Description: https://datasheet.octopart.com:443/EMK107B7105KA-T-Taiyo-Yuden-datasheet-8857305.pdf?src-supplier=Master+Electronics; ComponentLink2URL: https://datasheet.octopart.com:443/EMK107B7105KA-T-Taiyo-Yuden-datasheet-8857305.pdf?src-supplier=Master+Electronics; ComponentLink3Description: https://datasheet.octopart.com:443/EMK107B7105KA-T-Taiyo-Yuden-datasheet-154807686.pdf?src-supplier=Master+Electronics; ComponentLink3URL: https://datasheet.octopart.com:443/EMK107B7105KA-T-Taiyo-Yuden-datasheet-154807686.pdf?src-supplier=Master+Electronics; ComponentLink4Description: https://datasheet.octopart.com:443/EMK107B7105KA-T-Taiyo-Yuden-datasheet-140424376.pdf?src-supplier=Master+Electronics; ComponentLink4URL: https://datasheet.octopart.com:443/EMK107B7105KA-T-Taiyo-Yuden-datasheet-140424376.pdf?src-supplier=Master+Electronics; ComponentLink5Description: https://datasheet.octopart.com:443/EMK107B7105KA-T-Taiyo-Yuden-datasheet-151625811.pdf?src-supplier=Farnell; ComponentLink5URL: https://datasheet.octopart.com:443/EMK107B7105KA-T-Taiyo-Yuden-datasheet-151625811.pdf?src-supplier=Farnell; ComponentLink6Description: https://datasheet.octopart.com:443/EMK107B7105KA-T-Taiyo-Yuden-datasheet-65850851.pdf?src-supplier=Newark; ComponentLink6URL: https://datasheet.octopart.com:443/EMK107B7105KA-T-Taiyo-Yuden-datasheet-65850851.pdf?src-supplier=Newark; ComponentLink7Description: https://datasheet.octopart.com:443/EMK107B7105KA-T-Taiyo-Yuden-datasheet-14717454.pdf?src-supplier=Newark; ComponentLink7URL: https://datasheet.octopart.com:443/EMK107B7105KA-T-Taiyo-Yuden-datasheet-14717454.pdf?src-supplier=Newark; ComponentLink8Description: https://datasheet.octopart.com:443/EMK107B7105KA-T-Taiyo-Yuden-datasheet-138738940.pdf?src-supplier=Taiyo+Yuden+SCT; ComponentLink8URL: https://datasheet.octopart.com:443/EMK107B7105KA-T-Taiyo-Yuden-datasheet-138738940.pdf?src-supplier=Taiyo+Yuden+SCT; ComponentLink9Description: https://datasheet.octopart.com:443/EMK107B7105KA-T-Taiyo-Yuden-datasheet-99784766.pdf?src-supplier=Future+Electronics; ComponentLink9URL: https://datasheet.octopart.com:443/EMK107B7105KA-T-Taiyo-Yuden-datasheet-99784766.pdf?src-supplier=Future+Electronics; ComponentLink10Description: https://datasheet.octopart.com:443/EMK107B7105KA-T-Taiyo-Yuden-datasheet-99833418.pdf?src-supplier=Future+Electronics; ComponentLink10URL: https://datasheet.octopart.com:443/EMK107B7105KA-T-Taiyo-Yuden-datasheet-99833418.pdf?src-supplier=Future+Electronics; ComponentLink11Description: https://datasheet.octopart.com:443/CEEMK107B7105KA-T-Taiyo-Yuden-datasheet-62282700.pdf?src-supplier=Electro+Sonic; ComponentLink11URL: https://datasheet.octopart.com:443/CEEMK107B7105KA-T-Taiyo-Yuden-datasheet-62282700.pdf?src-supplier=Electro+Sonic; ComponentLink12Description: https://datasheet.octopart.com:443/EMK107B7105KA-T-Taiyo-Yuden-datasheet-62109223.pdf?src-supplier=Electro+Sonic; ComponentLink12URL: https://datasheet.octopart.com:443/EMK107B7105KA-T-Taiyo-Yuden-datasheet-62109223.pdf?src-supplier=Electro+Sonic; ComponentLink13Description: https://datasheet.octopart.com:443/EMK107B7105KA-T-Taiyo-Yuden-datasheet-41181424.pdf?src-supplier=Electro+Sonic; ComponentLink13URL: https://datasheet.octopart.com:443/EMK107B7105KA-T-Taiyo-Yuden-datasheet-41181424.pdf?src-supplier=Electro+Sonic; ComponentLink14Description: https://datasheet.octopart.com/EMK107B7105KA-T-Taiyo-Yuden-datasheet-17272276.pdf; ComponentLink14URL: https://datasheet.octopart.com/EMK107B7105KA-T-Taiyo-Yuden-datasheet-17272276.pdf; ComponentLink15Description: https://datasheet.octopart.com:443/EMK107B7105KA-T-Taiyo-Yuden-datasheet-13693549.pdf?src-supplier=_legacy+Avnet; ComponentLink15URL: https://datasheet.octopart.com:443/EMK107B7105KA-T-Taiyo-Yuden-datasheet-13693549.pdf?src-supplier=_legacy+Avnet; ComponentLink16Description: https://datasheet.octopart.com:443/EMK107B7105KA-T-Taiyo-Yuden-datasheet-10851928.pdf?src-supplier=element14; ComponentLink16URL: https://datasheet.octopart.com:443/EMK107B7105KA-T-Taiyo-Yuden-datasheet-10851928.pdf?src-supplier=element14; ComponentLink17Description: https://datasheet.octopart.com:443/EMK107B7105KA-T-Taiyo-Yuden-datasheet-8434558.pdf?src-supplier=element14; ComponentLink17URL: https://datasheet.octopart.com:443/EMK107B7105KA-T-Taiyo-Yuden-datasheet-8434558.pdf?src-supplier=element14; ComponentLink18Description: https://datasheet.octopart.com:443/EMK107B7105KA-T-Taiyo-Yuden-datasheet-10742123.pdf?src-supplier=Factory+Futures; ComponentLink18URL: https://datasheet.octopart.com:443/EMK107B7105KA-T-Taiyo-Yuden-datasheet-10742123.pdf?src-supplier=Factory+Futures; Composition: Ceramic; Contact Plating: Nickel; Country of Origin: Japan, Mainland China, Malaysia, South Korea; Depth: 800µm; Dielectric: X7R; Dielectric Material: Ceramic; Export Control Classification Number (ECCN) Code: EAR99; Halogen Free: Halogen Free; Harmonized Tariff Schedule (HTS) Code: 8532.24.00.20; Height: 800µm; Introduction Date: 2007-09-13; Lead Free: Lead Free; Length: 1.6mm; Library Name: Simulation Generic Components.IntLib; Lifecycle Status: Production; Manufacturer Part Number: EMK107B7105KA-T; Material: Ceramic; Max Length: 1.7mm; Max Operating Temperature: 125°C; Max Thickness: 900µm; Max Width: 900µm; Min Length: 1.5mm; Min Operating Temperature: -55°C; Min Thickness: 700µm; Min Width: 700µm; Mounting Technology: Surface Mount; Number of Terminals: 2; Packaging: Cut Tape; Pins: 2; Pricing: 1=0.08, 10=0.009 (USD); Radiation Hardening: No; REACH SVHC: Yes; RoHS: Compliant; Stock: 456720; Supplier: DigiKey; Supplier Part Number: 587-1241-1-ND; Termination: SMD/SMT; Thickness: 889µm; Tolerance: 10%; Voltage Rating: 16V; Voltage Rating (DC): 16V; Width: 800µm
C7 = C0805C106K8PACTU: IRON_BUCK_CVOUT: 10V 10uF X5R ±10% 0805 Multilayer Ceramic Capacitors MLCC - SMD/SMT ROHS; Manufacturer: KEMET; Case Code (Imperial): 0805; Case/Package: 0805; Category: Ceramic Capacitors; China RoHS: Compliant; Component Kind: Standard; ComponentLink1Description: https://datasheet.octopart.com:443/C0805C106K8PACTU-KEMET-datasheet-178499189.pdf?src-supplier=IHS; ComponentLink1URL: https://datasheet.octopart.com:443/C0805C106K8PACTU-KEMET-datasheet-178499189.pdf?src-supplier=IHS; ComponentLink2Description: https://datasheet.octopart.com:443/C0805C106K8PACTU-KEMET-datasheet-137357760.pdf?src-supplier=element14+APAC; ComponentLink2URL: https://datasheet.octopart.com:443/C0805C106K8PACTU-KEMET-datasheet-137357760.pdf?src-supplier=element14+APAC; ComponentLink3Description: https://datasheet.octopart.com:443/C0805C106K8PACTU-Kemet-datasheet-22084244.pdf?src-supplier=element14+APAC; ComponentLink3URL: https://datasheet.octopart.com:443/C0805C106K8PACTU-Kemet-datasheet-22084244.pdf?src-supplier=element14+APAC; ComponentLink4Description: https://datasheet.octopart.com:443/C0805C106K8PACTU-KEMET-datasheet-149523945.pdf?src-supplier=Farnell; ComponentLink4URL: https://datasheet.octopart.com:443/C0805C106K8PACTU-KEMET-datasheet-149523945.pdf?src-supplier=Farnell; ComponentLink5Description: https://datasheet.octopart.com:443/C0805C106K8PACTU-KEMET-datasheet-181957630.pdf?src-supplier=Farnell; ComponentLink5URL: https://datasheet.octopart.com:443/C0805C106K8PACTU-KEMET-datasheet-181957630.pdf?src-supplier=Farnell; ComponentLink6Description: https://datasheet.octopart.com:443/C0805C106K8PACTU-KEMET-datasheet-101576169.pdf?src-supplier=Farnell; ComponentLink6URL: https://datasheet.octopart.com:443/C0805C106K8PACTU-KEMET-datasheet-101576169.pdf?src-supplier=Farnell; ComponentLink7Description: https://datasheet.octopart.com:443/C0805C106K8PACTU-Kemet-datasheet-500041.pdf?src-supplier=Farnell; ComponentLink7URL: https://datasheet.octopart.com:443/C0805C106K8PACTU-Kemet-datasheet-500041.pdf?src-supplier=Farnell; ComponentLink8Description: https://datasheet.octopart.com:443/C0805C106K8PACTU-Kemet-datasheet-10978697.pdf?src-supplier=Farnell; ComponentLink8URL: https://datasheet.octopart.com:443/C0805C106K8PACTU-Kemet-datasheet-10978697.pdf?src-supplier=Farnell; ComponentLink9Description: https://datasheet.octopart.com:443/C0805C106K8PACTU-Kemet-datasheet-10547421.pdf?src-supplier=Farnell; ComponentLink9URL: https://datasheet.octopart.com:443/C0805C106K8PACTU-Kemet-datasheet-10547421.pdf?src-supplier=Farnell; ComponentLink10Description: https://datasheet.octopart.com:443/C0805C106K8PAC-TU-Kemet-datasheet-7833651.pdf?src-supplier=Farnell; ComponentLink10URL: https://datasheet.octopart.com:443/C0805C106K8PAC-TU-Kemet-datasheet-7833651.pdf?src-supplier=Farnell; ComponentLink11Description: https://datasheet.octopart.com:443/C0805C106K8PAC-TU-Kemet-datasheet-7601896.pdf?src-supplier=Farnell; ComponentLink11URL: https://datasheet.octopart.com:443/C0805C106K8PAC-TU-Kemet-datasheet-7601896.pdf?src-supplier=Farnell; ComponentLink12Description: https://datasheet.octopart.com:443/C0805C106K8PAC-TU-Kemet-datasheet-5316294.pdf?src-supplier=Farnell; ComponentLink12URL: https://datasheet.octopart.com:443/C0805C106K8PAC-TU-Kemet-datasheet-5316294.pdf?src-supplier=Farnell; ComponentLink13Description: https://datasheet.octopart.com:443/C0805C106K8PAC-TU-Kemet-datasheet-5314837.pdf?src-supplier=Farnell; ComponentLink13URL: https://datasheet.octopart.com:443/C0805C106K8PAC-TU-Kemet-datasheet-5314837.pdf?src-supplier=Farnell; ComponentLink14Description: https://datasheet.octopart.com:443/C0805C106K8PAC-TU-Kemet-datasheet-8092359.pdf?src-supplier=Farnell; ComponentLink14URL: https://datasheet.octopart.com:443/C0805C106K8PAC-TU-Kemet-datasheet-8092359.pdf?src-supplier=Farnell; ComponentLink15Description: https://datasheet.octopart.com:443/C0805C106K8PAC-TU-Kemet-datasheet-5313890.pdf?src-supplier=Farnell; ComponentLink15URL: https://datasheet.octopart.com:443/C0805C106K8PAC-TU-Kemet-datasheet-5313890.pdf?src-supplier=Farnell; ComponentLink16Description: https://datasheet.octopart.com:443/C0805C106K8PAC-TU-Kemet-datasheet-5314449.pdf?src-supplier=Farnell; ComponentLink16URL: https://datasheet.octopart.com:443/C0805C106K8PAC-TU-Kemet-datasheet-5314449.pdf?src-supplier=Farnell; ComponentLink17Description: https://datasheet.octopart.com:443/C0805C106K8PAC-TU-Kemet-datasheet-5390537.pdf?src-supplier=Farnell; ComponentLink17URL: https://datasheet.octopart.com:443/C0805C106K8PAC-TU-Kemet-datasheet-5390537.pdf?src-supplier=Farnell; ComponentLink18Description: https://datasheet.octopart.com:443/C0805C106K8PAC-TU-Kemet-datasheet-5314627.pdf?src-supplier=Farnell; ComponentLink18URL: https://datasheet.octopart.com:443/C0805C106K8PAC-TU-Kemet-datasheet-5314627.pdf?src-supplier=Farnell; ComponentLink19Description: https://datasheet.octopart.com:443/C0805C106K8PAC-TU-Kemet-datasheet-5389132.pdf?src-supplier=Farnell; ComponentLink19URL: https://datasheet.octopart.com:443/C0805C106K8PAC-TU-Kemet-datasheet-5389132.pdf?src-supplier=Farnell; ComponentLink20Description: https://datasheet.octopart.com:443/C0805C106K8PAC-TU-Kemet-datasheet-5313836.pdf?src-supplier=Farnell; ComponentLink20URL: https://datasheet.octopart.com:443/C0805C106K8PAC-TU-Kemet-datasheet-5313836.pdf?src-supplier=Farnell; ComponentLink21Description: https://datasheet.octopart.com:443/C0805C106K8PAC-TU-Kemet-datasheet-8111010.pdf?src-supplier=Farnell; ComponentLink21URL: https://datasheet.octopart.com:443/C0805C106K8PAC-TU-Kemet-datasheet-8111010.pdf?src-supplier=Farnell; ComponentLink22Description: https://datasheet.octopart.com:443/C0805C106K8PAC-TU-Kemet-datasheet-5326906.pdf?src-supplier=Farnell; ComponentLink22URL: https://datasheet.octopart.com:443/C0805C106K8PAC-TU-Kemet-datasheet-5326906.pdf?src-supplier=Farnell; ComponentLink23Description: https://datasheet.octopart.com:443/C0805C106K8PAC-TU-Kemet-datasheet-7541751.pdf?src-supplier=Farnell; ComponentLink23URL: https://datasheet.octopart.com:443/C0805C106K8PAC-TU-Kemet-datasheet-7541751.pdf?src-supplier=Farnell; ComponentLink24Description: https://datasheet.octopart.com:443/C0805C106K8PAC-TU-Kemet-datasheet-7627733.pdf?src-supplier=Farnell; ComponentLink24URL: https://datasheet.octopart.com:443/C0805C106K8PAC-TU-Kemet-datasheet-7627733.pdf?src-supplier=Farnell; ComponentLink25Description: https://datasheet.octopart.com:443/C0805C106K8PAC-TU-Kemet-datasheet-5315946.pdf?src-supplier=Farnell; ComponentLink25URL: https://datasheet.octopart.com:443/C0805C106K8PAC-TU-Kemet-datasheet-5315946.pdf?src-supplier=Farnell; ComponentLink26Description: https://datasheet.octopart.com:443/C0805C106K8PACTU-Kemet-datasheet-5314969.pdf?src-supplier=Newark; ComponentLink26URL: https://datasheet.octopart.com:443/C0805C106K8PACTU-Kemet-datasheet-5314969.pdf?src-supplier=Newark; ComponentLink27Description: https://datasheet.octopart.com:443/C0805C106K8PACTU-KEMET-datasheet-176289603.pdf?src-supplier=Newark; ComponentLink27URL: https://datasheet.octopart.com:443/C0805C106K8PACTU-KEMET-datasheet-176289603.pdf?src-supplier=Newark; ComponentLink28Description: https://datasheet.octopart.com:443/C0805C106K8PACTU-Kemet-datasheet-10978831.pdf?src-supplier=Newark; ComponentLink28URL: https://datasheet.octopart.com:443/C0805C106K8PACTU-Kemet-datasheet-10978831.pdf?src-supplier=Newark; ComponentLink29Description: https://datasheet.octopart.com:443/C0805C106K8PACTU-KEMET-datasheet-134136060.pdf?src-supplier=Electro+Sonic; ComponentLink29URL: https://datasheet.octopart.com:443/C0805C106K8PACTU-KEMET-datasheet-134136060.pdf?src-supplier=Electro+Sonic; ComponentLink30Description: https://datasheet.octopart.com:443/C0805C106K8PACTU-KEMET-datasheet-110724400.pdf?src-supplier=RS+(Formerly+Allied+Electronics); ComponentLink30URL: https://datasheet.octopart.com:443/C0805C106K8PACTU-KEMET-datasheet-110724400.pdf?src-supplier=RS+(Formerly+Allied+Electronics); ComponentLink31Description: https://datasheet.octopart.com:443/C0805C106K8PACTU-Kemet-datasheet-464.pdf?src-supplier=RS+(Formerly+Allied+Electronics); ComponentLink31URL: https://datasheet.octopart.com:443/C0805C106K8PACTU-Kemet-datasheet-464.pdf?src-supplier=RS+(Formerly+Allied+Electronics); ComponentLink32Description: https://datasheet.octopart.com:443/C0805C106K8PACTU-KEMET-datasheet-83957821.pdf?src-supplier=TME; ComponentLink32URL: https://datasheet.octopart.com:443/C0805C106K8PACTU-KEMET-datasheet-83957821.pdf?src-supplier=TME; ComponentLink33Description: https://datasheet.octopart.com:443/C0805C106K8PACTU-KEMET-datasheet-68311489.pdf?src-supplier=TME; ComponentLink33URL: https://datasheet.octopart.com:443/C0805C106K8PACTU-KEMET-datasheet-68311489.pdf?src-supplier=TME; ComponentLink34Description: https://datasheet.octopart.com/C0805C106K8PACTU-KEMET-datasheet-84169754.pdf; ComponentLink34URL: https://datasheet.octopart.com/C0805C106K8PACTU-KEMET-datasheet-84169754.pdf; ComponentLink35Description: https://datasheet.octopart.com:443/C0805C106K8PACTU-Kemet-datasheet-14434331.pdf?src-supplier=Factory+Futures; ComponentLink35URL: https://datasheet.octopart.com:443/C0805C106K8PACTU-Kemet-datasheet-14434331.pdf?src-supplier=Factory+Futures; ComponentLink36Description: https://datasheet.octopart.com:443/C0805C106K8PACTU-Kemet-datasheet-11862051.pdf?src-supplier=Arrow+Electronics; ComponentLink36URL: https://datasheet.octopart.com:443/C0805C106K8PACTU-Kemet-datasheet-11862051.pdf?src-supplier=Arrow+Electronics; Depth: 1.25mm; Dielectric: X5R; Dielectric Material: Ceramic; Dissipation Factor: 10%; Height: 1.25mm; Lead Free: Lead Free; Length: 2mm; Library Name: Simulation Generic Components.IntLib; Lifecycle Status: Production; Manufacturer Part Number: C0805C106K8PACTU; Material: Ceramic; Max Operating Temperature: 85°C; Min Operating Temperature: -55°C; Mounting Technology: Surface Mount; Number of Terminals: 2; Packaging: Cut Tape; Pins: 2; Pricing: 1=0.13, 10=0.072, 50=0.05, 100=0.0434, 500=0.0323, 1000=0.02889 (USD); Radiation Hardening: No; REACH SVHC: Yes; RoHS: Compliant; Schedule B: 8532240020; Stock: 2619505; Supplier: DigiKey; Supplier Part Number: 399-C0805C106K8PACTUCT-ND; Termination: SMD/SMT; Thickness: 1.397mm; Tolerance: 10%; Voltage: 10V; Voltage Rating: 10V; Voltage Rating (DC): 10V; Width: 1.25mm
